# Altium schematic sheet PAGE2-BLE, POWER, LORA.SchDoc (continued)

## Library data of the parts
C1 C4-C6 C11 C13 C14 = 10uF /16V: TDK - CGA4J1X5R1C106K125AC - CAP, MLCC, X5R, 10UF, 16V, 0805; Component Kind: Standard; ComponentLink1Description: http://bcove.me/e1v54mtm; ComponentLink1URL: http://bcove.me/e1v54mtm; ComponentLink2Description: http://www.farnell.com/datasheets/1936565.pdf; ComponentLink2URL: http://www.farnell.com/datasheets/1936565.pdf; ComponentLink3Description: http://www.farnell.com/datasheets/1683658.pdf; ComponentLink3URL: http://www.farnell.com/datasheets/1683658.pdf; Library Name: Capacitors.IntLib; Manufacturer: TDK; Manufacturer Part Number: CGA4J1X5R1C106K125AC; RoHS: YES; Supplier 1: Farnell
  C1 C4-C6 C11: Supplier Part Number 1: 2320856
  C13 C14: Pricing 1: 5=0.41, 50=0.146, 250=0.131, 500=0.12, 1000=0.103 (GBP); Stock 1: 8974; Supplier Part Number 1: 2210948RL
C2 = 4u7  16V 0603: MURATA - GRM188R61C475KAAJD - CAP, MLCC, X5R, 4.7UF, 16V, 0603; Capacitance: 4.7; Capacitance Tolerance: ± 10%; Ceramic Capacitor Case: 0603 [1608 Metric]; Dielectric Characteristic: X5; Product Range: GRM Series; SVHC: No SVHC (17-Dec-2015)<!--FANDB--><div class="features-and-benefits-container"><div class="features-and-benefits-desc-container"><span class="features-and-benefits-container-desc">SMD Multilayer Ceramic Capacitor, 0603 [1608 Metric], 4.7 µF, 16 V, ± 10%, X5; Voltage Rating: 16; Automotive Qualification Standard: -; Component Kind: Standard; ComponentLink1Description: http://www.farnell.com/datasheets/2079168.pdf; ComponentLink1URL: http://www.farnell.com/datasheets/2079168.pdf; Library Name: Capacitors.IntLib; Manufacturer: MURATA; Manufacturer Part Number: GRM188R61C475KAAJD; MSL: MSL 1 - Unlimited; Operating Temperature Max: 85; Operating Temperature Min: -55; Packaging: Cut Tape; Pricing 1: 10=0.16, 100=0.0929, 500=0.076, 1000=0.0618, 2000=0.0605, 4000=0.0425, 20000=0.0409, 40000=0.0355 (GBP); RoHS: YES; Stock 1: 5038; Supplier 1: Farnell; Supplier Part Number 1: 2611924
C3 = 47nF / 50V: MULTICOMP - MC0603B473K500CT - CAP, MLCC, X7R, 47NF, 50V, 0603; Component Kind: Standard; ComponentLink1Description: http://www.farnell.com/datasheets/1901289.pdf; ComponentLink1URL: http://www.farnell.com/datasheets/1901289.pdf; Library Name: Capacitors.IntLib; Manufacturer: MULTICOMP; Manufacturer Part Number: MC0603B473K500CT; Pricing 1: 10=0.0102, 100=0.0086, 500=0.0069, 1000=0.0059, 2000=0.0051 (GBP); RoHS: YES; Stock 1: 34893; Supplier 1: Farnell; Supplier Part Number 1: 1759116
C7-C10 = 22u 6.3V 0805: MULTICOMP - MC0805X226M6R3CT - CAP, MLCC, X5R, 22UF, 0805; Capacitance: 22; Capacitance Tolerance: ± 20%; Capacitor Terminals: SMD; Ceramic Capacitor Case: 0805 [2012 Metric]; Dielectric Characteristic: X5; Product Range: MC Series; SVHC: No SVHC (07-Jul-2017); Voltage Rating: 6.3; Automotive Qualification Standard: -; Capacitance Tolerance ±: ± 20%; Component Kind: Standard; ComponentLink1Description: http://www.farnell.com/datasheets/1901289.pdf; ComponentLink1URL: http://www.farnell.com/datasheets/1901289.pdf; ComponentLink2Description: http://www.farnell.com/datasheets/1932399.pdf; ComponentLink2URL: http://www.farnell.com/datasheets/1932399.pdf; Library Name: Capacitors.IntLib; Manufacturer: MULTICOMP; Manufacturer Part Number: MC0805X226M6R3CT; MSL: -; Operating Temperature Max: 85; Operating Temperature Min: -55; Operating Temperature Range: -55°C to +85°C<!--FANDB--><div class="features-and-benefits-container"><div class="features-and-benefits-desc-container"><span class="features-and-benefits-container-desc">SMD Multilayer Ceramic Capacitor, 0805 [2012 Metric], 22 µF, 6.3 V, ± 20%, X5R, MC Series</span></div><div class="features-and-benefits-description"><span class="features-benefits-value">The Multicomp MC series is general purpose MLCC capacitors with X5R dielectric material which provides high electrical precision, stability and reliability. This MLCC capacitor consists of a conducting material and electrodes with high density and high efficiency ceramic condensers.</span></div><div class="features-and-benefits-list"><ul class="features-and-benefits-list-list"><li class="features-and-benefits-list-item">Small size with higher capacitance values</li><li class="features-and-benefits-list-item">0201, 0402, 0603, 0805, 1206, 1210, 1812 case sizes available</li><li class="features-and-benefits-list-item">Capacitance value ranging from 100pF to 220?F</li><li class="features-and-benefits-list-item">Capacitor with lead free termination (pure Tin)</li><li class="features-and-benefits-list-item">High electrical precision, stability and reliability</li><li class="features-and-benefits-list-item">Rated voltage of 4V, 6.3V, 10V, 16V, 25V, 50V</li><li class="features-and-benefits-list-item">Operating temperature of -55°C to +85°C</li><li class="features-and-benefits-list-item">Available in ±10% and ±20; Packaging: Cut Tape; Pricing 1: 5=0.128, 50=0.0738, 250=0.0507, 500=0.042, 1500=0.0358 (GBP); RoHS: YES; Stock 1: 74736; Supplier 1: Farnell; Supplier Part Number 1: 1759415
C12 = 100nF: MURATA - GRM188R71C104KA01J - CAP, MLCC, X7R, 0.1UF, 16V, 0603; Capacitance: 0.1; Capacitance Tolerance: ± 10%; Ceramic Capacitor Case: 0603 [1608 Metric]; Dielectric Characteristic: X7; Product Range: GRM Series; SVHC: No SVHC (20-Jun-2016; Voltage Rating: 16; Automotive Qualification Standard: -; Component Kind: Standard; ComponentLink1Description: http://www.farnell.com/datasheets/2189124.pdf; ComponentLink1URL: http://www.farnell.com/datasheets/2189124.pdf; Library Name: Capacitors.IntLib; Manufacturer: MURATA; Manufacturer Part Number: GRM188R71C104KA01J; MSL: MSL 1 - Unlimited; Operating Temperature Max: 125; Operating Temperature Min: -55; Packaging: Cut Tape; Pricing 1: 10=0.0145, 100=0.0126, 500=0.0081, 2500=0.0059, 5000=0.0052, 10000=0.0046, 50000=0.0036, 100000=0.0035 (GBP); RoHS: YES; Stock 1: 18297; Supplier 1: Farnell; Supplier Part Number 1: 2688519
CON1 = Micro USB CHINA 1: Library Name: USBConLibSCH.SchLib
CON2 CON3 = U.FL-R-SMT-1(10): HIROSE(HRS) - U.FL-R-SMT-1(10) - RF COAXIAL, U.FL, STRAIGHT JACK, 50OHM; Coaxial Termination: Surface Mount Vertical; Connector Mounting: PCB Mount; Connector Type: U.FL Coaxial; Frequency Max: 6; Impedance: 50; Coaxial Cable Types: -; Component Kind: Standard; ComponentLink1Description: http://www.farnell.com/cad/2110742.pdf; ComponentLink1URL: http://www.farnell.com/cad/2110742.pdf; ComponentLink2Description: http://bcove.me/8op6hfnt; ComponentLink2URL: http://bcove.me/8op6hfnt; ComponentLink3Description: http://www.farnell.com/datasheets/1947917.pdf; ComponentLink3URL: http://www.farnell.com/datasheets/1947917.pdf; ComponentLink4Description: http://www.farnell.com/cad/2115175.stp; ComponentLink4URL: http://www.farnell.com/cad/2115175.stp; Connector Body Style: Straight Jack; Contact Material: Brass; Contact Plating: Gold Plated Contacts; Library Name: PiRA-RTC-HAT-SchLib.SchLib; Manufacturer: HIROSE(HRS); Manufacturer Part Number: U.FL-R-SMT-1(10); Operating Temperature Max: 90; Operating Temperature Min: -40°C<!--FANDB--><div class="features-and-benefits-container"><div class="features-and-benefits-description"><span class="features-benefits-value">The U. FL-R-SMT-1(10) from Hirose is a straight ultra miniature SMT coaxial receptacle. These connectors offer high frequency performance from DC to 6GHz and impedance of 50 ohm to meet the frequency requirements of a wide variety of miniature equipments.</span></div><div class="features-and-benefits-list"><ul class="features-and-benefits-list-list"><li class="features-and-benefits-list-item">Phosphor bronze with gold plated contacts</li><li class="features-and-benefits-list-item">LCP insulator</li><li class="features-and-benefits-list-item">VSWR of 1.3max at 0 to 3GHz</li><li class="features-and-benefits-list-item">Operating temperature range from -40°C to 90; Pricing 1: 1=0.5, 25=0.49, 100=0.468, 150=0.446, 250=0.424, 1000=0.394 (GBP); Product Range: -; RoHS: YES; Stock 1: 118146; Supplier 1: Farnell; Supplier Part Number 1: 1688077
CON4 = 1066: HOLDER BATT COIN COMPACT 20MM; Manufacturer: Keystone Electronics; Approvals: UL; Body Material: Nylon,Glass; Number of Batteries: 1; Component Kind: Standard; ComponentLink1Description: https://datasheet.ciiva.com/3/1066-3994.pdf; ComponentLink1URL: https://datasheet.ciiva.com/3/1066-3994.pdf; ComponentLink2Description: https://datasheet.ciiva.com/1391/1718229-1391198.pdf; ComponentLink2URL: https://datasheet.ciiva.com/1391/1718229-1391198.pdf; ComponentLink3Description: https://datasheet.ciiva.com/12342/m60p3-4068-12342995.pdf; ComponentLink3URL: https://datasheet.ciiva.com/12342/m60p3-4068-12342995.pdf; ComponentLink4Description: https://datasheet.ciiva.com/18403/70181436-18403177.pdf; ComponentLink4URL: https://datasheet.ciiva.com/18403/70181436-18403177.pdf; ComponentLink5Description: https://datasheet.ciiva.com/22643/1814063-22643020.pdf; ComponentLink5URL: https://datasheet.ciiva.com/22643/1814063-22643020.pdf; ComponentLink6Description: https://datasheet.ciiva.com/16322/m60-2p3-16322780.pdf; ComponentLink6URL: https://datasheet.ciiva.com/16322/m60-2p3-16322780.pdf; Contact Material: Bronze,Copper,Tin; Contact Plating: Tin; Diameter: 20mm; Lead Free: Lead Free; Manufacturer Part Number: 1066; Material: Bronze; Max Operating Temperature: 145°C; Min Operating Temperature: -50°C; Mount: Through Hole,Holder,PCB; Number of Cells: 1; Package Quantity: 1; Packaging: Bulk; Pricing 1: 1=1, 50=0.97, 100=0.947, 500=0.927, 1000=0.847 (EUR); REACH SVHC: No SVHC; Stock 1: 17073; Supplier 1: Farnell; Supplier Part Number 1: 2293258; Termination: PC Pin; Library Name: pira-smart-schlib.SchLib
D1 = RS1J-E3/61T..: VISHAY - RS1J-E3/61T.. - DIODE, FAST, 1A, 600V, SMD; Alternate Case Style: DO-214; Current Ifsm: 30; Diode Configuration: Single; Diode Type: Fast Recovery; External Depth: 2.79; External Length / Height: 2.29; External Width: 5.28; Forward Current If(AV): 1; Forward Voltage: 1.3; Junction Temperature Tj Max: 150; No. of Pins: 2 Pin; Product Range: RS1; Repetitive Reverse Voltage Vrrm Max: 600; Reverse Recovery Time trr Max: 250; SVHC: No SVHC (15-Jun-2015); Automotive Qualification Standard: -; Component Kind: Standard; ComponentLink1Description: http://www.vishay.com/docs/88869/packagin.pdf; ComponentLink1URL: http://www.vishay.com/docs/88869/packagin.pdf; ComponentLink2Description: http://www.vishay.com/doc?88707; ComponentLink2URL: http://www.vishay.com/doc?88707; ComponentLink3Description: http://www.farnell.com/datasheets/2244878.pdf; ComponentLink3URL: http://www.farnell.com/datasheets/2244878.pdf; Diode Case Style: DO-214; Forward Current If Max: 1; Forward Surge Current Ifsm Max: 30; Forward Voltage VF Max: 1.3; Library Name: Diodes.IntLib; Manufacturer: VISHAY; Manufacturer Part Number: RS1J-E3/61T..; Operating Temperature Max: 150; Operating Temperature Min: -55; Operating Temperature Range: -55°C to +150; Packaging: Cut Tape; Pricing 1: 10=0.164, 100=0.111, 200=0.093, 500=0.0847, 1000=0.083, 3000=0.0813, 9000=0.0796, 30000=0.0779 (GBP); RoHS: YES; Stock 1: 4705; Supplier 1: Farnell; Supplier Part Number 1: 9550143; Tape Width: 12mm<!--FANDB--><div class="features-and-benefits-container"><div class="features-and-benefits-desc-container"><span class="features-and-benefits-container-desc">Fast / Ultrafast Diode, 600 V, 1 A, Single, 1.3 V, 250 ns, 30 A</span></div><div class="features-and-benefits-description"><span class="features-benefits-value">The RS1J-E3/5AT is a surface-mount Fast Switching Rectifier with solderable matte tin-plated terminals. It is suitable for use in fast switching rectification of power supply, inverters, converters and freewheeling diodes.</span></div><div class="features-and-benefits-list"><ul class="features-and-benefits-list-list"><li class="features-and-benefits-list-item">Colour band denotes cathode end</li><li class="features-and-benefits-list-item">Low-profile package</li><li class="features-and-benefits-list-item">Ideal for automated placement</li><li class="features-and-benefits-list-item">Glass-passivated pallet chip junction</li><li class="features-and-benefits-list-item">Fast switching for high efficiency</li><li class="features-and-benefits-list-item">High forward surge capability</li><li class="features-and-benefits-list-item">Meets MSL level 1 as per J-STD-020, LF maximum peak of 260°C</li><li class="features-and-benefits-list-item">AEC-Q101 qualified</li><li class="features-and-benefits-list-item">UL94V-0 Flammability rating</li></ul></div><div class="features-and-benefits-applications"><ul class="features-and-benefits-value"><li class="features-and-benefits-list-item">Power Management</li><li class="features-and-benefits-list-item">Consumer Electronics</li><li class="features-and-benefits-list-item">Commercial</li><li class="features-and-benefits-list-item">Communications & Networking</li><li class="features-and-benefits-list-item">Automotive</li></ul></div></div>
D2 D7 D8 = LED RED: BROADCOM LIMITED - HSMS-C190 - LED, RED, 10MCD, 626NM; Forward Current If: 20; Forward Voltage: 2.1; LED Mounting: SMD; Lens Shape: Rectangular; Luminous Intensity: 10; SVHC: No SVHC (17-Dec-2015)<!--FANDB--><div class="features-and-benefits-container"><div class="features-and-benefits-description"><span class="features-benefits-value">The HSMS-C190 is a HER SMD Chip LED with untinted/diffused lens, made of GaP. It is designed in an industry standard package 1.6 x 0.8mm footprint with 0.8mm profile. It is compatible with IR reflow solder processes. The small size and wide viewing angle make this LED prime choices for backlighting applications and front panel illumination especially where space is a premium.</span></div><div class="features-and-benefits-list"><ul class="features-and-benefits-list-list"><li class="features-and-benefits-list-item">Small size</li><li class="features-and-benefits-list-item">Industry standard footprint</li><li class="features-and-benefits-list-item">Compatible with IR solder</li><li class="features-and-benefits-list-item">Diffused optics</li><li class="features-and-benefits-list-item">-40 to 85; Viewing Angle: 170°; Wavelength Typ: 626; Automotive Qualification Standard: -; Bulb Size: -; Component Kind: Standard; ComponentLink1Description: http://www.farnell.com/datasheets/313031.pdf; ComponentLink1URL: http://www.farnell.com/datasheets/313031.pdf; ComponentLink2Description: http://www.farnell.com/datasheets/1681524.pdf; ComponentLink2URL: http://www.farnell.com/datasheets/1681524.pdf; ComponentLink3Description: http://www.farnell.com/datasheets/2165483.pdf; ComponentLink3URL: http://www.farnell.com/datasheets/2165483.pdf; LED Colour: Red; Library Name: Diodes.IntLib; Manufacturer: BROADCOM LIMITED; Manufacturer Part Number: HSMS-C190; MSL: -; Packaging: Cut Tape; Pricing 1: 1=0.361, 10=0.256, 50=0.235, 100=0.106, 200=0.101 (GBP); Product Range: -; RoHS: YES; Stock 1: 7534; Supplier 1: Farnell; Supplier Part Number 1: 2497356
D3 D4 = SE30AFG-M3/6A: VISHAY - SE30AFG-M3/6A - RECTIFIER, ESD, 400V, 3A, DO-221AC; Diode Case Style: DO-221; Diode Configuration: Single; Diode Type: Standard Recovery; Forward Current If(AV): 3; Forward Surge Current Ifsm Max: 40; Forward Voltage VF Max: 1.1; No. of Pins: 2; Product Range: SE30; Repetitive Reverse Voltage Vrrm Max: 400; Reverse Recovery Time trr Max: 1.5; Automotive Qualification Standard: -; Component Kind: Standard; ComponentLink1Description: http://www.farnell.com/datasheets/2049981.pdf; ComponentLink1URL: http://www.farnell.com/datasheets/2049981.pdf; Library Name: Diodes_Schlib.SchLib; Manufacturer: VISHAY; Manufacturer Part Number: SE30AFG-M3/6A; MSL: MSL 1 - Unlimited; Operating Temperature Max: 175; Operating Temperature Min: -55°C<!--FANDB--><div class="features-and-benefits-container"><div class="features-and-benefits-desc-container"><span class="features-and-benefits-container-desc">Standard Recovery Diode, 400 V, 3 A, Single, 1.1 V, 1.5 µs, 40 A</span></div><div class="features-and-benefits-description"><span class="features-benefits-value">The SE30AFG-M3/6A is a surface-mount ESD Capability Rectifier with matte tin-plated solderable leads. It is suitable for use in general-purpose, polarity protection and rail-to-rail protection.</span></div><div class="features-and-benefits-list"><ul class="features-and-benefits-list-list"><li class="features-and-benefits-list-item">Oxide planar chip junction</li><li class="features-and-benefits-list-item">Low forward voltage drop, low leakage current</li><li class="features-and-benefits-list-item">Very low profile - Typical height of 0.95; Packaging: Each; Pricing 1: 1=0.306, 25=0.0765, 100=0.0753, 250=0.0729, 500=0.072, 1000=0.0654 (GBP); RoHS: YES; Stock 1: 13984; Supplier 1: Farnell; Supplier Part Number 1: 2313878
D5 = BZT52C6V2: DIODES INC. - BZT52C6V2 - DIODE, ZENER, 6.2V, 0.5W, SOD-123; No. of Pins: 2; Power Dissipation Pd: 500; Product Range: BZT52 Series; SVHC: No SVHC (12-Jan-2017); Zener Tolerance ±: 6%; Zener Voltage Vz Typ: 6.2; Automotive Qualification Standard: -; Component Kind: Standard; ComponentLink1Description: http://www.farnell.com/datasheets/1670962.pdf; ComponentLink1URL: http://www.farnell.com/datasheets/1670962.pdf; Diode Case Style: SOD-123; Library Name: Diodes_Schlib.SchLib; Manufacturer: DIODES INC.; Manufacturer Part Number: BZT52C6V2; MSL: MSL 1 - Unlimited; Operating Temperature Max: 150; Operating Temperature Min: -65; Operating Temperature Range: -65°C to +150°C<!--FANDB--><div class="features-and-benefits-container"><div class="features-and-benefits-desc-container"><span class="features-and-benefits-container-desc">Zener Single Diode, 6.2 V, 500 mW, SOD-123, 6 %, 2 Pins, 150 °C</span></div><div class="features-and-benefits-description"><span class="features-benefits-value">The BZT52C6V2 is a surface mount Zener Diode with moulded plastic case, matte tin annealed over Alloy 42 lead frame (lead-free plating) terminals and cathode band polarity. It is ideally suited for automated assembly processes.</span></div><div class="features-and-benefits-list"><ul class="features-and-benefits-list-list"><li class="features-and-benefits-list-item">Planar die construction</li><li class="features-and-benefits-list-item">500mW Power dissipation</li><li class="features-and-benefits-list-item">General purpose, medium current</li><li class="features-and-benefits-list-item">Totally lead-free</li><li class="features-and-benefits-list-item">Halogen and antimony-free - Green device</li><li class="features-and-benefits-list-item">Qualified to AEC-Q101 standards for high reliability</li></ul></div><div class="features-and-benefits-applications"><ul class="features-and-benefits-value"><li class="features-and-benefits-list-item">Automation & Process Control</li></ul></div></div>; Packaging: Cut Tape; Pricing 1: 1=0.415, 25=0.264, 100=0.0727, 250=0.0546, 500=0.047, 1000=0.0334, 3000=0.0278, 7000=0.0236 (GBP); RoHS: YES; Stock 1: 4408; Supplier 1: Farnell; Supplier Part Number 1: 1902438
D6 = MM3Z3V0T1G: ON SEMICONDUCTOR - MM3Z3V0T1G - ZENER DIODE, 200MW, 3V, SOD-323; No. of Pins: 2; Power Dissipation Pd: 300; Product Range: MM3Z3 Series; SVHC: No SVHC (07-Jul-2017); Zener Tolerance ±: 5%; Zener Voltage Vz Typ: 3; Automotive Qualification Standard: -; Component Kind: Standard; ComponentLink1Description: http://www.farnell.com/datasheets/1876691.pdf; ComponentLink1URL: http://www.farnell.com/datasheets/1876691.pdf; ComponentLink2Description: http://www.farnell.com/datasheets/2306645.pdf; ComponentLink2URL: http://www.farnell.com/datasheets/2306645.pdf; Diode Case Style: SOD-323; Library Name: Diodes.IntLib; Manufacturer: ON SEMICONDUCTOR; Manufacturer Part Number: MM3Z3V0T1G; MSL: MSL 1 - Unlimited<!--FANDB--><div class="features-and-benefits-container"><div class="features-and-benefits-desc-container"><span class="features-and-benefits-container-desc">Zener Single Diode, 3 V, 300 mW, SOD-323, 5 %, 2 Pins, 150 °C</span></div></div>; Operating Temperature Max: 150; Packaging: Cut Tape; Pricing 1: 5=0.126, 50=0.0544, 250=0.0443, 500=0.0288, 1500=0.0274 (GBP); RoHS: YES; Stock 1: 6591; Supplier 1: Farnell; Supplier Part Number 1: 2463510
F1 = PTC FUSE 3A: LITTELFUSE - MINISMDC150F/24-2 - POLYSWITCH, SMD, 1812, 1.5A; Component Kind: Standard; ComponentLink1Description: http://www.farnell.com/datasheets/2363925.pdf; ComponentLink1URL: http://www.farnell.com/datasheets/2363925.pdf; ComponentLink2Description: http://www.farnell.com/datasheets/2282569.pdf; ComponentLink2URL: http://www.farnell.com/datasheets/2282569.pdf; Library Name: Fuse_SchLib.SchLib; Manufacturer: LITTELFUSE; Manufacturer Part Number: MINISMDC150F/24-2; Pricing 1: 1=0.463, 50=0.382, 100=0.327, 250=0.302, 500=0.256, 1000=0.254, 5000=0.253, 10000=0.251 (GBP); RoHS: YES; Stock 1: 9256; Supplier 1: Farnell; Supplier Part Number 1: 1345929
L1 L2 = 1.5uH 5.1A: MURATA - FDSD0420-H-1R5M=P3 - INDUCTOR, SHIELDED, 1.5UH, 5.1A, 20%; DC Resistance Max: 0.036; Inductance: 1.5; Power Inductor Case: 4.2mm x 4.2mm x 2; Product Range: FDSD0420 Series; RMS Current (Irms): 5.1; Saturation Current (Isat): 7.7; SVHC: No SVHC (17-Dec-2015); Component Kind: Standard; ComponentLink1Description: http://www.farnell.com/datasheets/2021980.pdf; ComponentLink1URL: http://www.farnell.com/datasheets/2021980.pdf; Inductance Tolerance: ± 20%; Inductor Construction: Shielded; Library Name: Inductors.IntLib; Manufacturer: MURATA; Manufacturer Part Number: FDSD0420-H-1R5M=P3; MSL: MSL 1 - Unlimited<!--FANDB--><div class="features-and-benefits-container"></div>; Packaging: Each; Pricing 1: 5=0.79, 50=0.448, 250=0.422, 500=0.377, 1000=0.305, 2000=0.298, 10000=0.291, 20000=0.284 (GBP); RoHS: YES; Stock 1: 1471; Supplier 1: Farnell; Supplier Part Number 1: 2530091
L3 = PFL2015-222MEB: Fixed Inductors PFL2015 Shld Power 2.2 uH 20 % 1 A; Manufacturer: Coilcraft; Core Material: (Not Applicable); DC Current Rating: 1A; DC Resistance Max: 0.21ohm; Inductance Tolerance: ± 20%; Inductor Case Style: SMD; Product Range: Coilcraft - PFL2015 Series; Self Resonant Frequency: 100MHz; SVHC: To Be Advised; Component Kind: Standard; ComponentLink1Description: https://datasheet.ciiva.com/22701/1681966-22701687.pdf; ComponentLink1URL: https://datasheet.ciiva.com/22701/1681966-22701687.pdf; ComponentLink2Description: https://datasheet.ciiva.com/22679/1681966-22679157.pdf; ComponentLink2URL: https://datasheet.ciiva.com/22679/1681966-22679157.pdf; Inductance: 2.2µH; Manufacturer Part Number: PFL2015-222MEB; Pricing 1: 1=0.475, 200=0.383, 500=0.333 (EUR); Stock 1: 3300; Supplier 1: Farnell; Library Name: pira-smart-schlib.SchLib
P1 P3 P7 = Header 2: Header, 2-Pin; LatestRevisionDate: 17-Jul-2002; LatestRevisionNote: Re-released for DXP Platform.; Library Name: Miscellaneous Connectors.IntLib; Signal Integrity: Connector; Publisher: Altium Limited
P5 = Header 8: Header, 8-Pin; LatestRevisionDate: 17-Jul-2002; LatestRevisionNote: Re-released for DXP Platform.; Library Name: Miscellaneous Connectors.IntLib; Signal Integrity: Connector; Publisher: Altium Limited
P6 = Header 20X2: Header, 20-Pin, Dual row; LatestRevisionDate: 17-Jul-2002; LatestRevisionNote: Re-released for DXP Platform.; Library Name: Miscellaneous Connectors.IntLib; Signal Integrity: Connector; Publisher: Altium Limited
P8 = Header 4: Header, 4-Pin; Component Kind: Standard; LatestRevisionDate: 17-Jul-2002; LatestRevisionNote: Re-released for DXP Platform.; Library Name: Miscellaneous Connectors.IntLib; Signal Integrity: Connector; Publisher: Altium Limited
R1 R14 R28 R29 = 470R: MULTICOMP - MCMR06X471 JTL - RES, CERAMIC, 470R, 5%, 0.1W, 0603; Component Kind: Standard; ComponentLink1Description: http://www.farnell.com/datasheets/1716707.pdf; ComponentLink1URL: http://www.farnell.com/datasheets/1716707.pdf; Library Name: Resistors.IntLib; Manufacturer: MULTICOMP; Manufacturer Part Number: MCMR06X471 JTL; Pricing 1: 10=0.0089, 100=0.0076, 500=0.0063, 1000=0.0049, 2500=0.0033, 5000=0.0024, 25000=0.0016, 50000=0.0013 (GBP); RoHS: Y-EX; Stock 1: 3786; Supplier 1: Farnell; Supplier Part Number 1: 2073513
R2 R4 R11 R26 R27 R34 = 0R: BOURNS - CR0603-J/-000ELF - RES, THICK FILM, 0R, 5%, 0.1W, 0603; Power Rating: 100; Product Range: CR Series; Resistance: 0; Resistor Case Style: 0603 [1608 Metric]; Resistor Mounting: Surface Mount Device<!--FANDB--><div class="features-and-benefits-container"><div class="features-and-benefits-description"><span class="features-benefits-value">The Bourns CR series are standard thick film chip resistors. These resistors provide flexibility of performance, space saving and low cost. The Resistance range includes E96 and E24 decade values from 1ohm to 10Mohm.</span></div><div class="features-and-benefits-list"><ul class="features-and-benefits-list-list"><li class="features-and-benefits-list-item">0201, 0402, 0603, 0805, 1206, 1210, 2010, 2512 standard case sizes available</li><li class="features-and-benefits-list-item">±1% and ±5% tolerance</li><li class="features-and-benefits-list-item">±100ppm/°C, ±200ppm/°C and ±250ppm/°C TCR values available</li><li class="features-and-benefits-list-item">Power rating selectable for 50mW, 63mW, 0.1W, 0.125W, 0.25W, 0.5W and 1W based on ordering</li><li class="features-and-benefits-list-item">Jumpers are available for all size</li><li class="features-and-benefits-list-item">3 layer termination process with nickel barrier prevents leaching & provides excellent solderability</li><li class="features-and-benefits-list-item">Suitable for all type of soldering processes</li><li class="features-and-benefits-list-item">Tight dimensional tolerances</li><li class="features-and-benefits-list-item">Maximum working voltage depends on case size</li><li class="features-and-benefits-list-item">Operating temperature of -55°C to +125°C(CR0201) and -55°C to +155; SVHC: No SVHC (17-Dec-2015); Voltage Rating: 50; Automotive Qualification Standard: -; Component Kind: Standard; ComponentLink1Description: http://www.bourns.com/engineering/CHPREZTR/cr0603.stp; ComponentLink1URL: http://www.bourns.com/engineering/CHPREZTR/cr0603.stp; ComponentLink2Description: http://www.farnell.com/datasheets/2178762.pdf; ComponentLink2URL: http://www.farnell.com/datasheets/2178762.pdf; Library Name: Resistors.IntLib; Manufacturer: BOURNS; Manufacturer Part Number: CR0603-J/-000ELF; MSL: MSL 1 - Unlimited; Packaging: Cut Tape; Pricing 1: 10=0.0146, 250=0.0097, 1000=0.0073, 5000=0.0049, 12500=0.0042 (GBP); Resistance Tolerance: ± 5%; Resistor Element Material: Thick Film; RoHS: YES; Stock 1: 58200; Supplier 1: Farnell; Supplier Part Number 1: 2008343; Temperature Coefficient: -
R3 = 5k6: MULTICOMP - MCMR06X5601FTL - RES, CERAMIC, 5K6, 1%, 0.1W, 0603; Power Rating: 100; Product Range: MCMR Series; Resistance: 5.6; Resistor Case Style: 0603 [1608 Metric]; Resistor Mounting: Surface Mount Device<!--FANDB--><div class="features-and-benefits-container"><div class="features-and-benefits-desc-container"><span class="features-and-benefits-container-desc">SMD Chip Resistor, Ceramic, 5.6 kohm, 75 V, 0603 [1608 Metric], 100 mW, ± 1%, MCMR Series</span></div><div class="features-and-benefits-description"><span class="features-benefits-value">The Multicomp MCMR series resistors are automotive grade, anti sulphured thick film chip resistor. The resistance range includes E24 and E96 decade values from 1ohm to 10Mohm. These resistors are constructed on top of a high grade ceramic body.</span></div><div class="features-and-benefits-list"><ul class="features-and-benefits-list-list"><li class="features-and-benefits-list-item">EIA 0402, 0603, 0805, 1206 chip sizes available</li><li class="features-and-benefits-list-item">High reliability and stability</li><li class="features-and-benefits-list-item">Automotive grade AEC-Q200 compliant</li><li class="features-and-benefits-list-item">Complaint with (IEC 60068-1) 55/155/56 climatic category</li><li class="features-and-benefits-list-item">Maximum operation voltage of 50V, 75V, 150V and 200V</li><li class="features-and-benefits-list-item">Power rating of 62.5mW, 100mW, 125mW and 250mW</li><li class="features-and-benefits-list-item">Available in ±1% and ±5% tolerance</li><li class="features-and-benefits-list-item">Temperature coefficient (TCR) of +200ppm/°C, +100ppm/°C and -200ppm/°C to +400ppm/°C</li><li class="features-and-benefits-list-item">Operating temperature range -55°C to 155; SVHC: No SVHC (07-Jul-2017); Temperature Coefficient: ± 100; Voltage Rating: 75; Automotive Qualification Standard: -; Component Kind: Standard; ComponentLink1Description: http://www.farnell.com/datasheets/1716707.pdf; ComponentLink1URL: http://www.farnell.com/datasheets/1716707.pdf; Library Name: Resistors.IntLib; Manufacturer: MULTICOMP; Manufacturer Part Number: MCMR06X5601FTL; Packaging: Cut Tape; Pricing 1: 10=0.0088, 100=0.0053, 500=0.0037, 1000=0.0029, 2500=0.0024 (GBP); Resistance Tolerance: ± 1%; Resistor Element Material: Ceramic; RoHS: Y-EX; Stock 1: 9135; Supplier 1: Farnell; Supplier Part Number 1: 2073537
R5 = 3k9: MULTICOMP - MCWR06X3901FTL - RES, THICK FILM, 3K9, 1%, 0.1W, 0603; Power Rating: 100; Product Range: MCWR Series; Resistance: 3.9; Resistor Case Style: 0603 [1608 Metric]; SVHC: No SVHC (07-Jul-2017)<!--FANDB--><div class="features-and-benefits-container"><div class="features-and-benefits-desc-container"><span class="features-and-benefits-container-desc">SMD Chip Resistor, Thick Film, 3.9 kohm, 50 V, 0603 [1608 Metric], 100 mW, ± 1; Temperature Coefficient: ± 100; Voltage Rating: 50; Automotive Qualification Standard: -; Component Kind: Standard; ComponentLink1Description: http://www.farnell.com/datasheets/1849611.pdf; ComponentLink1URL: http://www.farnell.com/datasheets/1849611.pdf; Library Name: Resistors.IntLib; Manufacturer: MULTICOMP; Manufacturer Part Number: MCWR06X3901FTL; Packaging: Cut Tape; Pricing 1: 10=0.0032, 100=0.002, 500=0.0013, 1000=0.0011, 2500=0.0009 (GBP); Resistance Tolerance: ± 1%; Resistor Element Material: Thick Film; RoHS: Y-EX; Stock 1: 19057; Supplier 1: Farnell; Supplier Part Number 1: 2447363
R6 R9 R10 R15 R21-R23 = 10k: MULTICOMP - MCMR06X103 JTL - RES, CERAMIC, 10K, 5%, 0.1W, 0603; Automotive Qualification Standard: -<!--FANDB--><div class="features-and-benefits-container"><div class="features-and-benefits-description"><span class="features-benefits-value">The Multicomp MCMR series resistors are automotive grade, anti sulphured thick film chip resistor. The resistance range includes E24 and E96 decade values from 1ohm to 10Mohm. These resistors are constructed on top of a high grade ceramic body.</span></div><div class="features-and-benefits-list"><ul class="features-and-benefits-list-list"><li class="features-and-benefits-list-item">EIA 0402, 0603, 0805, 1206 chip sizes available</li><li class="features-and-benefits-list-item">High reliability and stability</li><li class="features-and-benefits-list-item">Automotive grade AEC-Q200 compliant</li><li class="features-and-benefits-list-item">Complaint with (IEC 60068-1) 55/155/56 climatic category</li><li class="features-and-benefits-list-item">Maximum operation voltage of 50V, 75V, 150V and 200V</li><li class="features-and-benefits-list-item">Power rating of 62.5mW, 100mW, 125mW and 250mW</li><li class="features-and-benefits-list-item">Available in ±1% and ±5% tolerance</li><li class="features-and-benefits-list-item">Temperature coefficient (TCR) of +200ppm/°C, +100ppm/°C and -200ppm/°C to +400ppm/°C</li><li class="features-and-benefits-list-item">Operating temperature range -55°C to 155; Power Rating: 100; Product Range: MCMR Series; Resistance: 10; Resistor Case Style: 0603 [1608 Metric]; Temperature Coefficient: ± 100; Voltage Rating: 75; Component Kind: Standard; ComponentLink1Description: http://www.farnell.com/datasheets/1716707.pdf; ComponentLink1URL: http://www.farnell.com/datasheets/1716707.pdf; Library Name: Resistors.IntLib; Manufacturer: MULTICOMP; Manufacturer Part Number: MCMR06X103 JTL; Packaging: Cut Tape; Pricing 1: 10=0.0087, 100=0.0074, 500=0.0061, 1000=0.0048, 2500=0.0032, 5000=0.0024, 25000=0.0016, 50000=0.0013 (GBP); Resistance Tolerance: ± 5%; Resistor Element Material: Ceramic; RoHS: Y-EX; Stock 1: 19791; Supplier 1: Farnell; Supplier Part Number 1: 2073356
R7 = 220R: MULTICOMP - MCWR06X2200FTL - RES, THICK FILM, 220R, 1%, 0.1W, 0603; Power Rating: 100; Product Range: MCWR Series; Resistance: 220; Resistor Case Style: 0603 [1608 Metric]; SVHC: No SVHC (07-Jul-2017)<!--FANDB--><div class="features-and-benefits-container"><div class="features-and-benefits-desc-container"><span class="features-and-benefits-container-desc">SMD Chip Resistor, Thick Film, 220 ohm, 50 V, 0603 [1608 Metric], 100 mW, ± 1; Temperature Coefficient: ± 100; Voltage Rating: 50; Automotive Qualification Standard: -; Component Kind: Standard; ComponentLink1Description: http://www.farnell.com/datasheets/1849611.pdf; ComponentLink1URL: http://www.farnell.com/datasheets/1849611.pdf; Library Name: Resistors.IntLib; Manufacturer: MULTICOMP; Manufacturer Part Number: MCWR06X2200FTL; Packaging: Cut Tape; Pricing 1: 10=0.0031, 100=0.0018, 500=0.0013, 1000=0.001, 2500=0.0008 (GBP); Resistance Tolerance: ± 1%; Resistor Element Material: Thick Film; RoHS: Y-EX; Stock 1: 124834; Supplier 1: Farnell; Supplier Part Number 1: 2447298
R8 = 150k: MULTICOMP - MCWR06X1503FTL - RES, THICK FILM, 150KOHM, 1%, 0.1W; Power Rating: 100; Product Range: MCWR Series; Resistance: 150; Resistor Case Style: 0603 [1608 Metric]; SVHC: No SVHC (07-Jul-2017)<!--FANDB--><div class="features-and-benefits-container"><div class="features-and-benefits-desc-container"><span class="features-and-benefits-container-desc">SMD Chip Resistor, Thick Film, 150 kohm, 50 V, 0603 [1608 Metric], 100 mW, ± 1; Temperature Coefficient: ± 100; Voltage Rating: 50; Automotive Qualification Standard: -; Component Kind: Standard; ComponentLink1Description: http://www.farnell.com/datasheets/1849611.pdf; ComponentLink1URL: http://www.farnell.com/datasheets/1849611.pdf; Library Name: Resistors.IntLib; Manufacturer: MULTICOMP; Manufacturer Part Number: MCWR06X1503FTL; Packaging: Cut Tape; Pricing 1: 10=0.0033, 100=0.002, 500=0.0014, 1000=0.0011, 2500=0.0009 (GBP); Resistance Tolerance: ± 1%; Resistor Element Material: Thick Film; RoHS: Y-EX; Stock 1: 11455; Supplier 1: Farnell; Supplier Part Number 1: 2447254
R12 = 10R: VISHAY - RCA060310R0FKEA - RES, AUTO, THICK FILM, 10R, 1%, 0603; Automotive Qualification Standard: AEC-Q200; Power Rating: 100; Product Range: RCA Series; Resistance: 10; Resistor Case Style: 0603 [1608 Metric]; SVHC: No SVHC (17-Dec-2015)<!--FANDB--><div class="features-and-benefits-container"><div class="features-and-benefits-description"><span class="features-benefits-value">The RCA series from Vishay are automotive grade, sulphur resistant thick film rectangular chip resistors. The resistance range includes E24 and E96 decade values from 1ohm to 10Mohm. They provide superior resistance against H2S atmosphere. These resistors have metal glaze on high quality ceramic.</span></div><div class="features-and-benefits-list"><ul class="features-and-benefits-list-list"><li class="features-and-benefits-list-item">Automotive grade AEC-Q200 qualified (rev C)</li><li class="features-and-benefits-list-item">EIA 0402, 0603, 0805, 1206, 1210, 1218, 2010 and 2512 case sizes available</li><li class="features-and-benefits-list-item">Available in ±0.5%, ±1% and ±5% tolerances</li><li class="features-and-benefits-list-item">Jumpers are also available</li><li class="features-and-benefits-list-item">±50ppm/K, ±100ppm/K and ±200ppm/K TCR values</li><li class="features-and-benefits-list-item">Power rating of 0.063W, 0.10W, 0.125W, 0.25W, 0.5W, 0.75W and 1W at 70?C</li><li class="features-and-benefits-list-item">Available in 50V, 75V, 150V, 200V, 400V and 500V limiting voltage options</li><li class="features-and-benefits-list-item">Category temperature range from -55?C to 155?C</li><li class="features-and-benefits-list-item">Stability ?R/R = 1 % for 1000h at 70; Temperature Coefficient: ± 100; Voltage Rating: 75; Component Kind: Standard; ComponentLink1Description: http://www.farnell.com/datasheets/2111201.pdf; ComponentLink1URL: http://www.farnell.com/datasheets/2111201.pdf; Library Name: Resistors.IntLib; Manufacturer: VISHAY; Manufacturer Part Number: RCA060310R0FKEA; Packaging: Cut Tape; Pricing 1: 10=0.0803, 100=0.0386, 500=0.0235, 2500=0.015, 5000=0.0135, 10000=0.0108, 50000=0.0068, 100000=0.0067 (GBP); Resistance Tolerance: ± 1%; Resistor Element Material: Thick Film; RoHS: YES; Stock 1: 9559; Supplier 1: Farnell; Supplier Part Number 1: 2616585
R13 = 33k: MULTICOMP - MCWR06X3302FTL - RES, THICK FILM, 33K, 1%, 0.1W, 0603; Power Rating: 100; Product Range: MCWR Series; Resistance: 33; Resistor Case Style: 0603 [1608 Metric]; SVHC: No SVHC (07-Jul-2017)<!--FANDB--><div class="features-and-benefits-container"><div class="features-and-benefits-desc-container"><span class="features-and-benefits-container-desc">SMD Chip Resistor, Thick Film, 33 kohm, 50 V, 0603 [1608 Metric], 100 mW, ± 1; Temperature Coefficient: ± 100; Voltage Rating: 50; Automotive Qualification Standard: -; Component Kind: Standard; ComponentLink1Description: http://www.farnell.com/datasheets/1849611.pdf; ComponentLink1URL: http://www.farnell.com/datasheets/1849611.pdf; Library Name: Resistors.IntLib; Manufacturer: MULTICOMP; Manufacturer Part Number: MCWR06X3302FTL; Packaging: Cut Tape; Pricing 1: 10=0.0033, 100=0.002, 500=0.0014, 1000=0.0011, 2500=0.0009 (GBP); Resistance Tolerance: ± 1%; Resistor Element Material: Thick Film; RoHS: Y-EX; Stock 1: 10138; Supplier 1: Farnell; Supplier Part Number 1: 2447342
R16 R17 R20 R31 R33 = 100k: MULTICOMP - MCMR06X104 JTL - RES, CERAMIC, 100K, 5%, 0.1W, 0603; Power Rating: 100; Product Range: MCMR Series; Resistor Case Style: 0603 [1608 Metric]; Resistor Mounting: Surface Mount Device<!--FANDB--><div class="features-and-benefits-container"><div class="features-and-benefits-description"><span class="features-benefits-value">The Multicomp MCMR series resistors are automotive grade, anti sulphured thick film chip resistor. The resistance range includes E24 and E96 decade values from 1ohm to 10Mohm. These resistors are constructed on top of a high grade ceramic body.</span></div><div class="features-and-benefits-list"><ul class="features-and-benefits-list-list"><li class="features-and-benefits-list-item">EIA 0402, 0603, 0805, 1206 chip sizes available</li><li class="features-and-benefits-list-item">High reliability and stability</li><li class="features-and-benefits-list-item">Automotive grade AEC-Q200 compliant</li><li class="features-and-benefits-list-item">Complaint with (IEC 60068-1) 55/155/56 climatic category</li><li class="features-and-benefits-list-item">Maximum operation voltage of 50V, 75V, 150V and 200V</li><li class="features-and-benefits-list-item">Power rating of 62.5mW, 100mW, 125mW and 250mW</li><li class="features-and-benefits-list-item">Available in ±1% and ±5% tolerance</li><li class="features-and-benefits-list-item">Temperature coefficient (TCR) of +200ppm/°C, +100ppm/°C and -200ppm/°C to +400ppm/°C</li><li class="features-and-benefits-list-item">Operating temperature range -55°C to 155; Temperature Coefficient: ± 100; Voltage Rating: 75; Automotive Qualification Standard: -; Component Kind: Standard; ComponentLink1Description: http://www.farnell.com/datasheets/1716707.pdf; ComponentLink1URL: http://www.farnell.com/datasheets/1716707.pdf; Library Name: Resistors.IntLib; Manufacturer: MULTICOMP; Manufacturer Part Number: MCMR06X104 JTL; Packaging: Cut Tape; Pricing 1: 10=0.0109, 100=0.0085, 500=0.006, 1000=0.0048, 2500=0.004, 5000=0.003, 25000=0.002, 50000=0.0016 (GBP); Resistance: 100; Resistance Tolerance: ± 5%; Resistor Element Material: Ceramic; RoHS: Y-EX; Stock 1: 187; Supplier 1: Farnell; Supplier Part Number 1: 2073357
R18 R19 R30 = 100R: MULTICOMP - MC0063W06031100R - RES, THICK FILM, 100R, 1%, 0.063W, 0603; External Depth: 0.45; External Length / Height: 1.6; External Width: 0.8; No. of Pins: 2; Power Rating: 63; Product Range: MC Series; Reel Quantity: 5000; Resistance: 100; Resistor Case Style: 0603 [1608 Metric]; Resistor Mounting: Surface Mount Device; SVHC: No SVHC (12-Jan-2017); Temperature Coeff. +: 200; Temperature Coefficient: ± 200; Voltage Rating: 50; Voltage Rating V DC: 50V<!--FANDB--><div class="features-and-benefits-container"><div class="features-and-benefits-description"><span class="features-benefits-value">The Multicomp MC series current sense resistors are thick film chip resistors. The resistance range includes E96 and E24 decade values from 1ohm to 10Mohm.</span></div><div class="features-and-benefits-list"><ul class="features-and-benefits-list-list"><li class="features-and-benefits-list-item">EIA 0402, 0603, 0805, 1206 chip sizes available</li><li class="features-and-benefits-list-item">Small size and low weight</li><li class="features-and-benefits-list-item">Suitable for both wave and reflow soldering</li><li class="features-and-benefits-list-item">Reduction of assembly costs</li><li class="features-and-benefits-list-item">Maximum working voltage of 50V(0402, 0603), 150V(0805), 200V(1206)</li><li class="features-and-benefits-list-item">Available in ±1%, ±2% and ±5% tolerance</li><li class="features-and-benefits-list-item">Power rating of 62.5mW, 100mW and 125mW</li><li class="features-and-benefits-list-item">±400ppm/°C(1ohm to 10ohm), ±200ppm/°C(11ohm to 100ohm), ±100; Automotive Qualification Standard: -; Component Kind: Standard; ComponentLink1Description: http://www.farnell.com/datasheets/1788326.pdf; ComponentLink1URL: http://www.farnell.com/datasheets/1788326.pdf; ComponentLink2Description: http://www.farnell.com/cad/1561149.zip; ComponentLink2URL: http://www.farnell.com/cad/1561149.zip; ComponentLink3Description: http://www.farnell.com/datasheets/2289252.pdf; ComponentLink3URL: http://www.farnell.com/datasheets/2289252.pdf; Library Name: Resistors.IntLib; Manufacturer: MULTICOMP; Manufacturer Part Number: MC0063W06031100R; Operating Temperature Max: 155; Operating Temperature Min: -55; Operating Temperature Range: -55°C to +155; Packaging: Cut Tape; Resistance Tolerance: ± 1%; Resistor Element Material: Thick Film; RoHS: Y-EX; Supplier 1: Farnell; Supplier Part Number 1: 2447227; Tape Width: 8; Temperature Coeff. -: 200; Tolerance +: 1%; Tolerance -: 1%
R24 R25 = 4k7: MULTICOMP - MCMR06X4701FTL - RES, CERAMIC, 4K7, 1%, 0.1W, 0603; Power Rating: 100; Product Range: MCMR Series; Resistance: 4.7; Resistor Case Style: 0603 [1608 Metric]; SVHC: No SVHC (12-Jan-2017)<!--FANDB--><div class="features-and-benefits-container"><div class="features-and-benefits-description"><span class="features-benefits-value">The Multicomp MCMR series resistors are automotive grade, anti sulphured thick film chip resistor. The resistance range includes E24 and E96 decade values from 1ohm to 10Mohm. These resistors are constructed on top of a high grade ceramic body.</span></div><div class="features-and-benefits-list"><ul class="features-and-benefits-list-list"><li class="features-and-benefits-list-item">EIA 0402, 0603, 0805, 1206 chip sizes available</li><li class="features-and-benefits-list-item">High reliability and stability</li><li class="features-and-benefits-list-item">Automotive grade AEC-Q200 compliant</li><li class="features-and-benefits-list-item">Complaint with (IEC 60068-1) 55/155/56 climatic category</li><li class="features-and-benefits-list-item">Maximum operation voltage of 50V, 75V, 150V and 200V</li><li class="features-and-benefits-list-item">Power rating of 62.5mW, 100mW, 125mW and 250mW</li><li class="features-and-benefits-list-item">Available in ±1% and ±5% tolerance</li><li class="features-and-benefits-list-item">Temperature coefficient (TCR) of +200ppm/°C, +100ppm/°C and -200ppm/°C to +400ppm/°C</li><li class="features-and-benefits-list-item">Operating temperature range -55°C to 155; Temperature Coefficient: ± 100; Voltage Rating: 75; Automotive Qualification Standard: -; Component Kind: Standard; ComponentLink1Description: http://www.farnell.com/datasheets/1716707.pdf; ComponentLink1URL: http://www.farnell.com/datasheets/1716707.pdf; Library Name: Resistors.IntLib; Manufacturer: MULTICOMP; Manufacturer Part Number: MCMR06X4701FTL; Packaging: Cut Tape; Pricing 1: 10=0.0085, 100=0.0051, 500=0.0036, 1000=0.0028, 2500=0.0023 (GBP); Resistance Tolerance: ± 1%; Resistor Element Material: Ceramic; RoHS: Y-EX; Stock 1: 218618; Supplier 1: Farnell; Supplier Part Number 1: 2073509
R32 = 249k: MULTICOMP         MCWR06X2493FTL             SMD Chip Resistor, Thick Film, 249 kohm, 50 V, 0603 [1608 Metric], 100 mW,  1%, MCWR Series; Power Rating: 100mW; SVHC: No SVHC (17-Dec-2015); Temperature Coefficient: ± 100ppm/°C; Voltage Rating: 50V; Automotive Qualification Standard: -; Component Kind: Standard; ComponentLink1Description: http://www.farnell.com/datasheets/1849611.pdf; ComponentLink1URL: http://www.farnell.com/datasheets/1849611.pdf; Manufacturer: Multicomp; Manufacturer Part Number: MCWR06X2493FTL; MSL: -; Packaging: Cut Tape; Pricing 1: 10=0.0035, 100=0.0021, 500=0.0015, 1000=0.0012, 2500=0.001 (EUR); Product Range: MCWR Series; Resistance: 249kohm; Resistance Tolerance: ± 1%; Resistor Case Style: 0603 [1608 Metric]; Resistor Element Type: Thick Film; Stock 1: 5347; Supplier 1: Farnell; Supplier Part Number 1: 2447306; Library Name: pira-smart-schlib.SchLib
T1 = MMBT3904: ON SEMICONDUCTOR/FAIRCHILD - MMBT3904 - TRANSISTOR, NPN, SOT-23; Collector Emitter Saturation Voltage Vce(on): 200; Collector Emitter Voltage V(br)ceo: 40; Current Ic hFE: 10; DC Current Gain hFE: 300; Device Marking: BT3904; Hfe Min: 100; No. of Pins: 3; No. of Transistors: 1; Noise Factor Max: 5; Power Dissipation Pd: 350; SVHC: No SVHC (15-Jun-2015); Voltage Vcbo: 60V<!--FANDB--><div class="features-and-benefits-container"><div class="features-and-benefits-description"><span class="features-benefits-value">The MMBT3904 from Fairchild is a surface mount, NPN general purpose amplifier in SOT-23 package. This device is suitable for general purpose amplification and switching applications.</span></div><div class="features-and-benefits-list"><ul class="features-and-benefits-list-list"><li class="features-and-benefits-list-item">Collector to emitter voltage (Vce) of 40V</li><li class="features-and-benefits-list-item">Collector to emitter saturation voltage of 300mV at 50mA collector current</li><li class="features-and-benefits-list-item">Power dissipation of 350mW</li><li class="features-and-benefits-list-item">DC collector current of 200mA</li><li class="features-and-benefits-list-item">DC current gain of 30 at Ic=100mA</li><li class="features-and-benefits-list-item">Operating junction temperature range from -55°C to 150; Automotive Qualification Standard: -; Component Kind: Standard; ComponentLink1Description: http://www.farnell.com/datasheets/2304127.pdf; ComponentLink1URL: http://www.farnell.com/datasheets/2304127.pdf; ComponentLink2Description: http://www.farnell.com/datasheets/1942444.pdf; ComponentLink2URL: http://www.farnell.com/datasheets/1942444.pdf; Continuous Collector Current Ic Max: 200; Current Ic Continuous a Max: 200; DC Collector Current: 200; Gain Bandwidth ft Min: 300; Gain Bandwidth ft Typ: 300; Library Name: PiRA-RTC-HAT-SchLib.SchLib; Manufacturer: ON SEMICONDUCTOR/FAIRCHILD; Manufacturer Part Number: MMBT3904; MSL: MSL 1 - Unlimited; Operating Temperature Max: 150; Operating Temperature Min: -55; Operating Temperature Range: -55°C to +150; Packaging: Cut Tape; Power Dissipation Ptot Max: 350; Pricing 1: 5=0.121, 50=0.043, 250=0.0401, 500=0.0261, 1500=0.0256 (GBP); Product Range: -; RoHS: YES; SMD Marking: 1; Stock 1: 54090; Supplier 1: Farnell; Supplier Part Number 1: 9846727; Transistor Case Style: SOT-23; Transistor Polarity: NPN; Transition Frequency ft: 300
T2 = DMG6602SVT: DIODES INC. - DMG6602SVT - MOSFET, NP CH, , 30V, 3.4, ATSOT23; Continuous Drain Current Id: 3.4; Continuous Drain Current Id, P Channel: -2.8; Drain Source Voltage Vds: 30; Drain Source Voltage Vds, P Channel: -30; Module Configuration: Dual; No. of Pins: 6; On Resistance Rds(on): 0.038; On Resistance Rds(on), P Channel: 0.073; Power Dissipation Pd: 1.12; Rds(on) Test Voltage Vgs: 10; SVHC: No SVHC (17-Dec-2015); Threshold Voltage Vgs: 1; Transistor Case Style: TSOT-26; Transistor Polarity: N and P Channel; Automotive Qualification Standard: -; Component Kind: Standard; ComponentLink1Description: http://www.farnell.com/datasheets/1915929.pdf; ComponentLink1URL: http://www.farnell.com/datasheets/1915929.pdf; Continuous Drain Current Id, N Channel: 3.4; Drain Source Voltage Vds, N Channel: 30; Library Name: PiRA-RTC-HAT-PCB.IntLib; Manufacturer: DIODES INC.; Manufacturer Part Number: DMG6602SVT; MSL: -; On Resistance Rds(on), N Channel: 0.038; Operating Temperature Max: 150; Operating Temperature Min: -55; Operating Temperature Range: -55°C to +150°C<!--FANDB--><div class="features-and-benefits-container"><div class="features-and-benefits-description"><span class="features-benefits-value">The DMG6602SVT from Diode Inc is a surface mount complementary pair enhancement mode MOSFET in TSOT-26 package. This MOSFET features low input capacitance, fast switching speed and low input/output leakage, designed to minimize the onstate resistance and maintain superior switching performance, making it ideal for high efficiency power management applications and backlighting.</span></div><div class="features-and-benefits-list"><ul class="features-and-benefits-list-list"><li class="features-and-benefits-list-item">Automotive grade AEC-Q101 qualified</li><li class="features-and-benefits-list-item">UL recognized</li><li class="features-and-benefits-list-item">Drain to source voltage (Vds) of 30V</li><li class="features-and-benefits-list-item">Gate to source voltage (Vgs) of ±20V</li><li class="features-and-benefits-list-item">Continuous drain current of 3.4A</li><li class="features-and-benefits-list-item">Power dissipation (Pd) of 1.27W</li><li class="features-and-benefits-list-item">Operating temperature range -55°C to 150°C</li><li class="features-and-benefits-list-item">Low on state resistance of 38mohm at Vgs of 10; Pricing 1: 1=0.363, 25=0.339, 100=0.169, 250=0.166, 500=0.108, 1000=0.0809, 2000=0.0793, 6000=0.0777 (GBP); Product Range: -; RoHS: YES; Stock 1: 4881; Supplier 1: Farnell; Supplier Part Number 1: 2061522
T6 = 2N7002,215: NEXPERIA - 2N7002,215 - MOSFET,N CH, 60V,0.3A,SOT23; Continuous Drain Current Id: 300; Device Marking: 12%; Drain Source Voltage Vds: 60; Junction Temperature Tj Max: 150; Junction Temperature Tj Min: -65; No. of Pins: 3; On Resistance Rds(on): 2.8; Power Dissipation Pd: 830; Pulse Current Idm: 1.2; Rds(on) Test Voltage Vgs: 10; SVHC: No SVHC (17-Dec-2015); Threshold Voltage Vgs: 2; Transistor Case Style: SOT-23; Transistor Polarity: N Channel; Voltage Vgs Max: 30; Voltage Vgs th Max: 2.5V<!--FANDB--><div class="features-and-benefits-container"><div class="features-and-benefits-desc-container"><span class="features-and-benefits-container-desc">MOSFET Transistor, N Channel, 300 mA, 60 V, 2.8 ohm, 10 V, 2 V</span></div><div class="features-and-benefits-description"><span class="features-benefits-value">The 2N7002,215 is a N-channel enhancement mode Field Effect Transistor (FET) in surface mount plastic package uses Trench MOSFET technology. Suitable for logic level gate drive sources and high-speed line drivers.</span></div><div class="features-and-benefits-list"><ul class="features-and-benefits-list-list"><li class="features-and-benefits-list-item">Logic-level compatible</li><li class="features-and-benefits-list-item">Very fast switching</li></ul></div><div class="features-and-benefits-applications"><ul class="features-and-benefits-value"><li class="features-and-benefits-list-item">Industrial</li></ul></div></div>; Automotive Qualification Standard: -; Component Kind: Standard; ComponentLink1Description: http://www.nxp.com/packings/SOT23_215.html; ComponentLink1URL: http://www.nxp.com/packings/SOT23_215.html; ComponentLink2Description: https://assets.nexperia.com/documents/spice-model/2N7002.prm; ComponentLink2URL: https://assets.nexperia.com/documents/spice-model/2N7002.prm; ComponentLink3Description: http://www.farnell.com/datasheets/1499179.pdf; ComponentLink3URL: http://www.farnell.com/datasheets/1499179.pdf; ComponentLink4Description: http://www.farnell.com/datasheets/1936237.pdf; ComponentLink4URL: http://www.farnell.com/datasheets/1936237.pdf; Current Id Max: 300; Junction to Case Thermal Resistance A: 150; Library Name: PiRA-RTC-HAT-SchLib.SchLib; Manufacturer: NEXPERIA; Manufacturer Part Number: 2N7002,215; MSL: MSL 1 - Unlimited; Operating Temperature Max: 150; Operating Temperature Min: -65; Packaging: Cut Tape; Pricing 1: 5=0.145, 50=0.0518, 250=0.0477, 500=0.0365, 1500=0.0349 (GBP); Product Range: -; RoHS: YES; Stock 1: 328913; Storage Temperature Max: 150; Storage Temperature Min: -65; Supplier 1: Farnell; Supplier Part Number 1: 1510761; Termination Type: Surface Mount Device; Voltage Vds Typ: 60; Voltage Vgs Rds on Measurement: 10
U1 = BQ24296RGET: IC LI+ CHARGER PWR MGMT 24VQFN; Manufacturer: Texas Instruments; Battery Chemistry: Lithium-Ion/Polymer; Battery Pack Voltage: 4.2V; Charge Current - Max: 3A; Current - Charging: Constant - Programmable; Fault Protection: Over Current, Over Temperature, Over Voltage; Interface: I²C; Number of Cells: 1; Voltage - Supply (Max): 6.2V; Category: Integrated Circuits (ICs); Component Kind: Standard; ComponentLink1Description: http://www.ti.com/lit/ds/symlink/bq24296.pdf; ComponentLink1URL: http://www.ti.com/lit/ds/symlink/bq24296.pdf; Library Name: PiRA-RTC-HAT-SchLib.SchLib; Manufacturer Part Number: BQ24296RGET; Operating Temperature: -40°C ~ 85°C (TA); Package / Case: 24-VFQFN Exposed Pad; Packaging: Cut Tape (CT); Part Status: Active; Programmable Features: -; RoHS: RoHS Compliant; Supplier 1: Farnell; Supplier Device Package: 24-VQFN (4x4); Supplier Part Number 1: 2373541
U2 = TPS630701RNMT: TEXAS INSTRUMENTS - TPS630701RNMT - DC/DC CONV, BUCK-BOOST, 2.4MHZ, VQFN-15; DC / DC Converter IC Case: VQFN; Input Voltage Max: 16; Input Voltage Min: 2; No. of Pins: 15; Output Voltage Nom.: 5; SVHC: No SVHC (17-Dec-2015; Switching Frequency: 2.4; Automotive Qualification Standard: -; Component Kind: Standard; ComponentLink1Description: http://www.ti.com/general/docs/lit/getliterature.tsp?genericPartNumber=TPS63070&fileType=pdf; ComponentLink1URL: http://www.ti.com/general/docs/lit/getliterature.tsp?genericPartNumber=TPS63070&fileType=pdf; Library Name: PiRA-RTC-HAT-SchLib.SchLib; Manufacturer: TEXAS INSTRUMENTS; Manufacturer Part Number: TPS630701RNMT; MSL: MSL 1 - Unlimited; Operating Temperature Max: 125; Output Current: 2; Packaging: Cut Tape; Pricing 1: 1=2.23, 10=1.87, 100=1.7, 250=1.56, 500=1.47, 1000=1.21 (GBP); Product Range: -; RoHS: YES; Stock 1: 456; Supplier 1: Farnell; Supplier Part Number 1: 2643432; Topology: Buck-Boost
U3 = TPS62740DSSR: Voltage Regulators - Switching Regulators 360-nA Iq SD Cnvtr w/ Intg Load Switch; Manufacturer: Texas Instruments; Efficiency: 90%; Max Input Voltage: 5.5V; Max Output Current: 300mA; Max Output Voltage: 3.3V; Min Input Voltage: 2.2V; Min Output Voltage: 1.8V; Number of Outputs: 1; Quiescent Current: 300nA; Switching Frequency: 2MHz; Component Kind: Standard; ComponentLink1Description: https://datasheet.ciiva.com/29899/tps62740-29899468.pdf; ComponentLink1URL: https://datasheet.ciiva.com/29899/tps62740-29899468.pdf; ComponentLink2Description: https://datasheet.ciiva.com/29567/tps62740-29567318.pdf; ComponentLink2URL: https://datasheet.ciiva.com/29567/tps62740-29567318.pdf; Lead Free: Lead Free; Manufacturer Part Number: TPS62740DSSR; Max Operating Temperature: 85°C; Min Operating Temperature: -40°C; Min Supply Voltage: 2.2V; Mount: Surface Mount; Number of Pins: 12; Operating Supply Current: 300mA; Operating Supply Voltage: 5.5V; Output Current: 300mA; Output Voltage: 3.3V; Package Quantity: 3000; Packaging: Tape and Reel; Pricing 1: 5=1.44, 50=1.1, 250=1.05, 500=0.707, 1500=0.688 (EUR); Radiation Hardening: No; REACH SVHC: No SVHC; Stock 1: 2440; Supplier 1: Farnell; Supplier Part Number 1: 2781752; Library Name: pira-smart-schlib.SchLib
U4 = Lora RFM95 868M: RFM95 ULTRA-LONG RANGE TRANSCEIV; Manufacturer: Seeed Technology Co., Ltd; Manufacturer Part Number: 109990166; Current - Receiving: 12.1mA; Current - Transmitting: 120mA; Data Rate: 300kbps; Frequency: 868MHz; Modulation: FSK, GFSK, GMSK, MSK, OOK; Power - Output: 20dBm; Protocol: LoRa™; RF Family/Standard: General ISM < 1GHz; Sensitivity: -148dBm; Serial Interfaces: SPI; Voltage - Supply: 1.8 V ~ 3.7 V; Antenna Type: -; Category: RF/IF and RFID; Component Kind: Standard; ComponentLink1Description: https://github.com/SeeedDocument/RFM95-98_LoRa_Module/blob/master/RFM95_96_97_98_DataSheet.pdf; ComponentLink1URL: https://github.com/SeeedDocument/RFM95-98_LoRa_Module/blob/master/RFM95_96_97_98_DataSheet.pdf; Library Name: Lora_Schlib.SchLib; Memory Size: -; Mounting Type: Surface Mount; Operating Temperature: -20°C ~ 70°C; Package / Case: Module; Packaging: Bulk; Part Status: Active; Pricing 1: 1=7.09 (USD); RoHS: Not Applicable; Stock 1: 211; Supplier 1: Digi-Key; Supplier Part Number 1: 1597-1488-ND
U5 = ISL1208IU8Z-T7A: IC RTC/CALENDAR I2C LP 8MSOP; Manufacturer: Intersil; Date Format: YY-MM-DD-dd; Interface: I2C,Serial,2-Wire; Logic Function: Clock; Max Supply Voltage: 5.5V; Memory Size: 2B; Min Supply Voltage: 2.7V; Time Format: HH:MM:SS; Case/Package: MSOP; Component Kind: Standard; ComponentLink1Description: https://datasheet.ciiva.com/16293/fn8085-16293145.pdf; ComponentLink1URL: https://datasheet.ciiva.com/16293/fn8085-16293145.pdf; ComponentLink2Description: https://datasheet.ciiva.com/8145/fn8085-8145071.pdf; ComponentLink2URL: https://datasheet.ciiva.com/8145/fn8085-8145071.pdf; ComponentLink3Description: https://datasheet.ciiva.com/6668/fn8085-6668119.pdf; ComponentLink3URL: https://datasheet.ciiva.com/6668/fn8085-6668119.pdf; ComponentLink4Description: https://datasheet.ciiva.com/6668/fn8085-6668117.pdf; ComponentLink4URL: https://datasheet.ciiva.com/6668/fn8085-6668117.pdf; ComponentLink5Description: https://datasheet.ciiva.com/6668/fn8085-6668118.pdf; ComponentLink5URL: https://datasheet.ciiva.com/6668/fn8085-6668118.pdf; Lead Free: Lead Free; Manufacturer Part Number: ISL1208IU8Z-T7A; Max Operating Temperature: 85°C; Min Operating Temperature: -40°C; Mount: Surface Mount; Non-volatile Memory Size: 2B; Number of Pins: 8; Package Quantity: 250; Packaging: Tape and Reel; Pricing 1: 1=2.63, 10=2.24, 25=2.12, 50=2.01, 100=1.89, 250=1.84, 500=1.65, 1000=1.37 (EUR); Radiation Hardening: No; REACH SVHC: Unknown; Stock 1: 321; Supplier 1: Farnell; Supplier Part Number 1: 2543322; Library Name: pira-smart-schlib.SchLib
X1 = LFXTAL009678: CRYSTAL, 32.768KHZ, 12.5PF, SMD; Manufacturer: IQD; Frequency: 32.8kHz; Case/Package: 217; Component Kind: Standard; ComponentLink1Description: https://datasheet.ciiva.com/46419/1852967-46419749.pdf; ComponentLink1URL: https://datasheet.ciiva.com/46419/1852967-46419749.pdf; Load Capacitance: 12.5pF; Manufacturer Part Number: LFXTAL009678; Max Operating Temperature: 85°C; Min Operating Temperature: -40°C; Number of Pins: 2; Pricing 1: 5=0.283, 50=0.203, 250=0.169, 500=0.163, 1500=0.157, 3000=0.152, 15000=0.146 (EUR); REACH SVHC: No SVHC; Stock 1: 15609; Supplier 1: Farnell; Supplier Part Number 1: 2449398; Library Name: pira-smart-schlib.SchLib
J1 = Jumper: Component Kind: Standard; Description: Jumper; Library Name: Jumper.IntLib
J2 = Socket: Description: Socket; LatestRevisionDate: 17-Jul-2002; LatestRevisionNote: Re-released for DXP Platform.; Library Name: Miscellaneous Connectors.IntLib; Signal Integrity: Connector; Publisher: Altium Limited
M1 = MDBT40: Component Kind: Standard; Description: MDBT40; Library Name: pira-smart-schlib.SchLib
